# Altium schematic sheet Esquematico1.schdoc(Esquematico1)
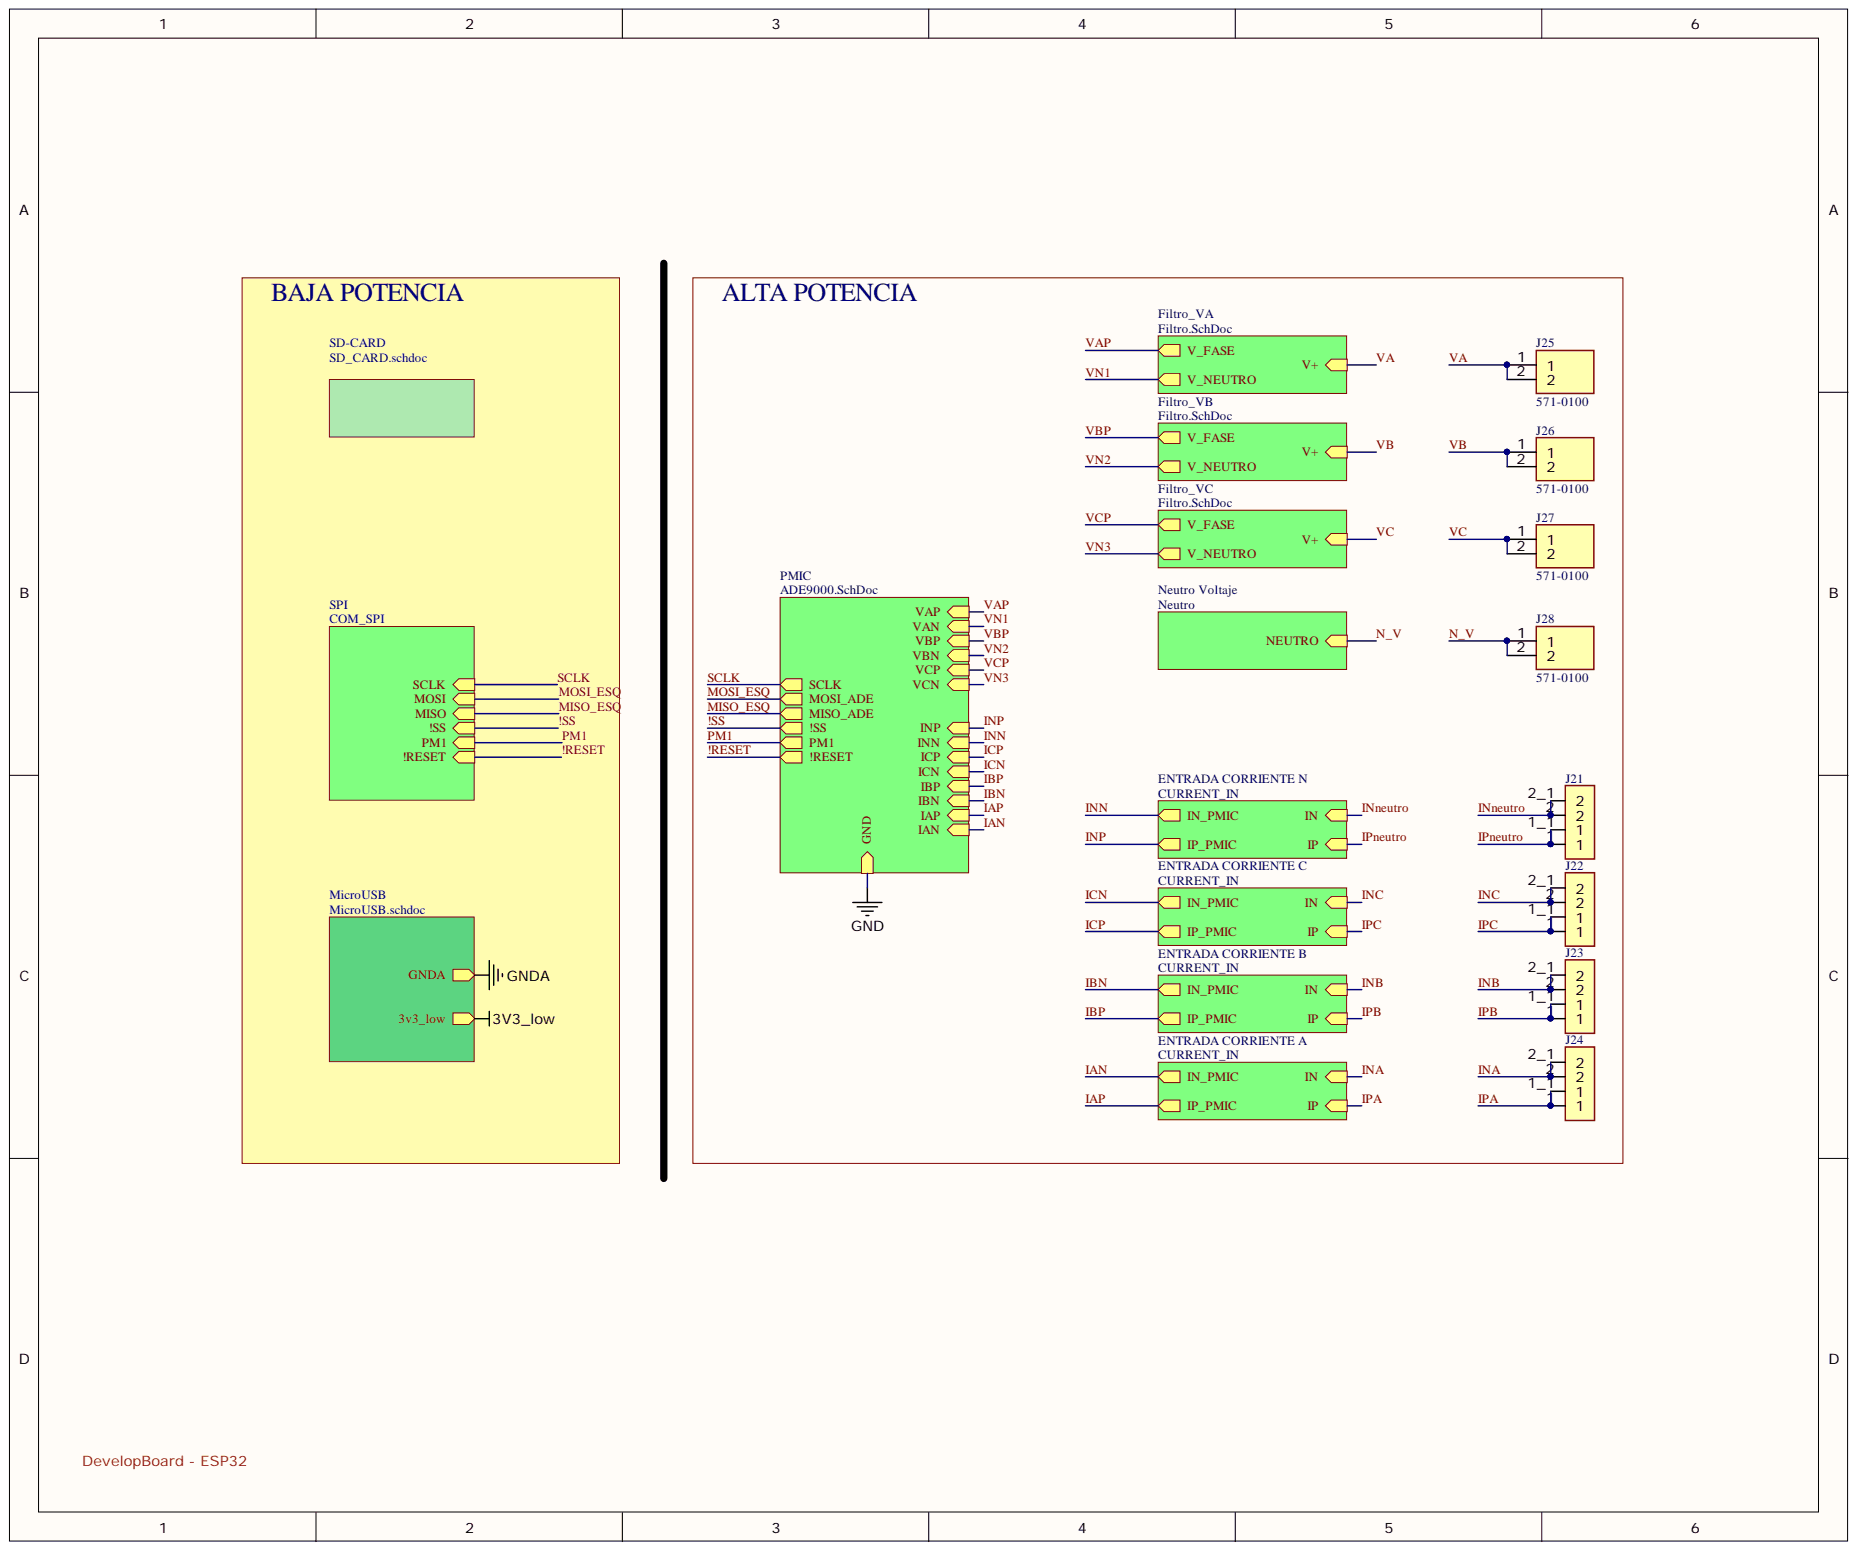
[diagram p0: Esquematico1.schdoc]

## Parts
J21  2834082-1  [TE_2834082-1]  (value not drawn)
J22  2834082-1  [TE_2834082-1]  (value not drawn)
J23  2834082-1  [TE_2834082-1]  (value not drawn)
J24  2834082-1  [TE_2834082-1]  (value not drawn)
J25  571-0100  [5710100]
J26  571-0100  [5710100]
J27  571-0100  [5710100]
J28  571-0100  [5710100]

## Nets
INA: J24.2 J24.2_1
INB: J23.2 J23.2_1
INC: J22.2 J22.2_1
INneutro: J21.2 J21.2_1
IPA: J24.1 J24.1_1
IPB: J23.1 J23.1_1
IPC: J22.1 J22.1_1
IPneutro: J21.1 J21.1_1
N_V: J28.1 J28.2
VA: J25.1 J25.2
VB: J26.1 J26.2
VC: J27.1 J27.2
3V3_low:
!RESET:
!SS:
GND:
GNDA:
IAN:
IAP:
IBN:
IBP:
ICN:
ICP:
INN:
INP:
MISO_ESQ:
MOSI_ESQ:
PM1:
SCLK:
VAP:
VBP:
VCP:
VN1:
VN2:
VN3:

## Library data of the parts
J21-J24 = 2834082-1: Conn PC Terminal Block 2 POS 5mm Solder ST Thru-Hole 20A/Contact Box/Carton; MF: TE Connectivity; TE_purchase_URL: https://www.te.com/usa-en/product-2834082-1.html?te_bu=Cor&te_type=disp&te_campaign=seda_glo_cor-seda-global-disp-prtnr-fy19-seda-model-bom-cta_sma-317_1&elqCampaignId=32493; SnapEDA_Link: https://www.snapeda.com/parts/2834082-1/TE%20Connectivity%20AMP%20Connectors/view-part/2471183/?ref=snap; Package: None; MP: 2834082-1; Library Name: 2834082-1.IntLib; Digi-Key_Purchase_URL: https://www.digikey.com/product-detail/en/te-connectivity-amp-connectors/2834082-1/A124223-ND/6012670?utm_source=snapeda&utm_medium=aggregator&utm_campaign=symbol; Digi-Key_Part_Number: A124223-ND; Component Kind: Standard
J25-J28 = 571-0100: Connector; Manufacturer_Name: Deltron; Mouser Price/Stock: https://www.mouser.co.uk/ProductDetail/Deltron/571-0100?qs=E2gf03EXmtoqC8%2FBhoPdrg%3D%3D; Mouser Part Number: 164-6218; Manufacturer_Part_Number: 571-0100; Height: 12.4mm; Datasheet Link: https://www.dem-uk.com/deltron-components/Data/Product_Downloads/deltron-571-0100-4mm-90deg-single-socket-data.pdf; Component Kind: Standard
